# Altium schematic sheet DP.SchDoc
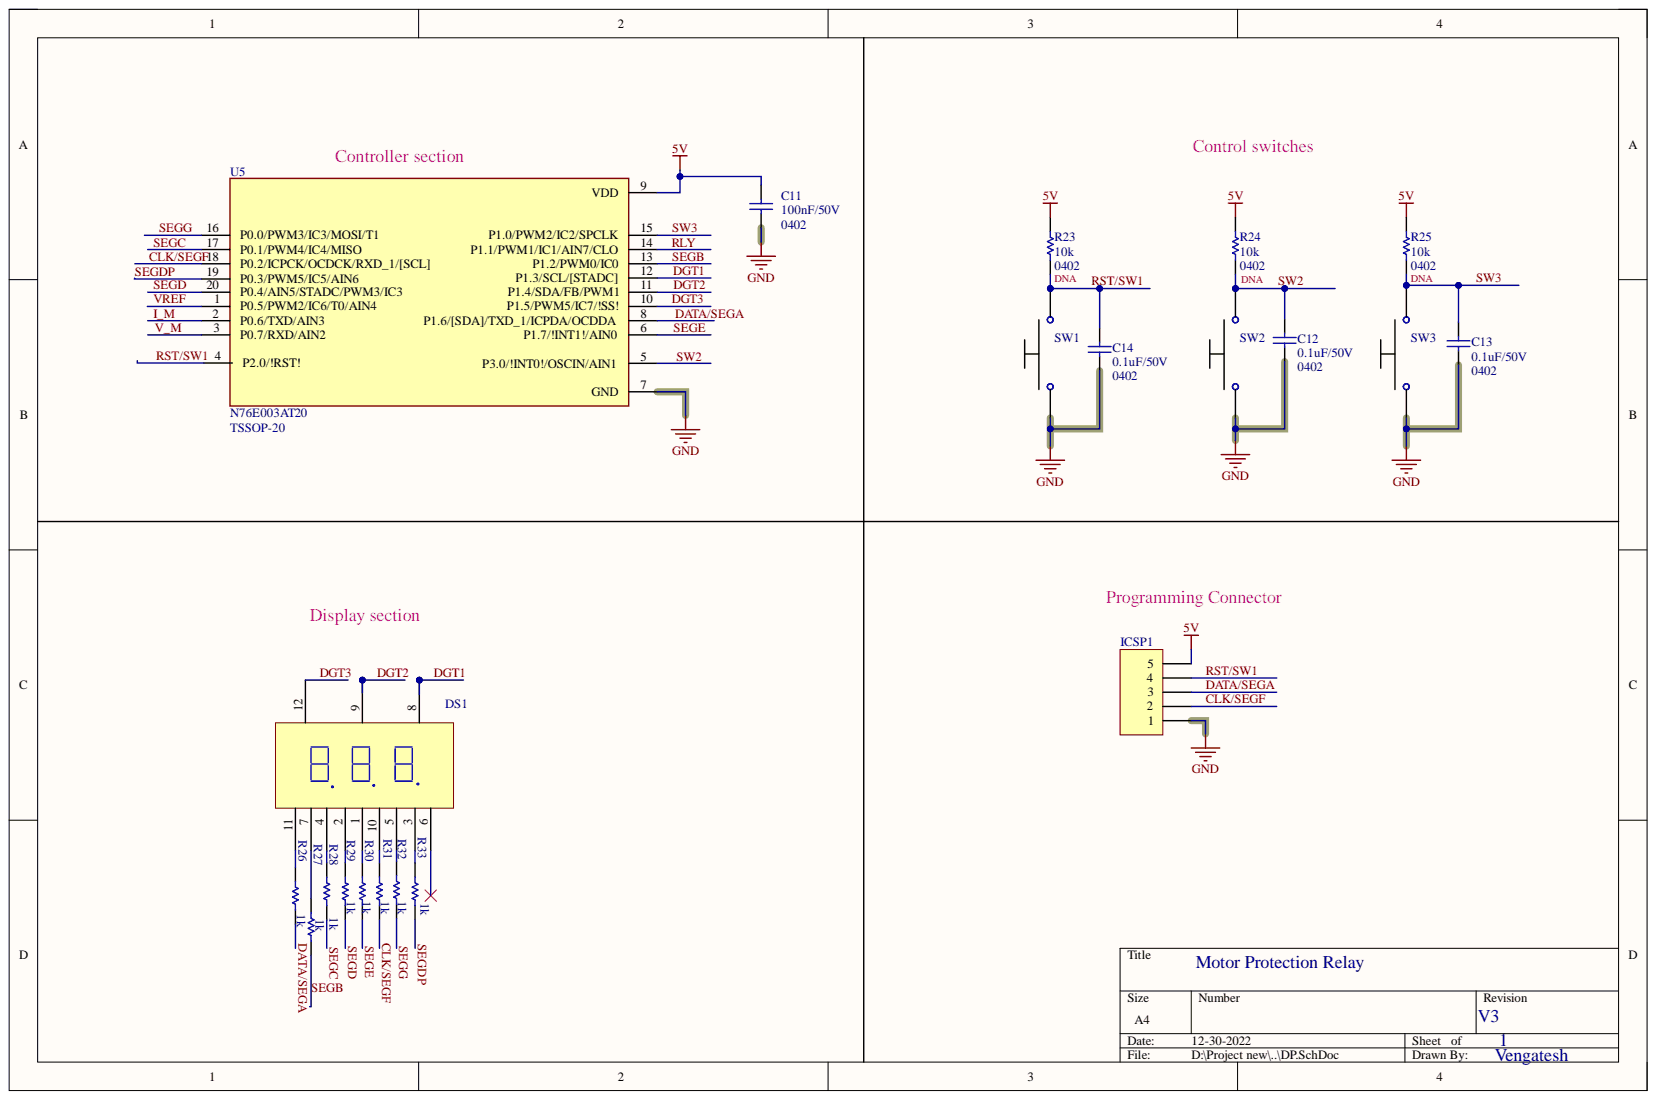
[diagram p0: DP.SchDoc]

## Parts
C11  value 100nF/50V  Package: 0402  [R_0402]  (hidden comment: Cap)
C12  value 0.1uF/50V  Package: 0402  [C_0402]  (hidden comment: Cap)
C13  value 0.1uF/50V  Package: 0402  [C_0402]  (hidden comment: Cap)
C14  value 0.1uF/50V  Package: 0402  [C_0402]  (hidden comment: Cap)
DS1  Display  [Seven Seg-3Digit]  (value not drawn)
ICSP1  Header 5  [HDR1X5]  (value not drawn)
R23  value 10k  Package: 0402  Populate: DNA  [R_0402]  (hidden comment: Res1)
R24  value 10k  Package: 0402  Populate: DNA  [R_0402]  (hidden comment: Res1)
R25  value 10k  Package: 0402  Populate: DNA  [R_0402]  (hidden comment: Res1)
R26  value 1k  [R_0402]  (hidden comment: Res1)
R27  value 1k  [R_0402]  (hidden comment: Res1)
R28  value 1k  [R_0402]  (hidden comment: Res1)
R29  value 1k  [R_0402]  (hidden comment: Res1)
R30  value 1k  [R_0402]  (hidden comment: Res1)
R31  value 1k  [R_0402]  (hidden comment: Res1)
R32  value 1k  [R_0402]  (hidden comment: Res1)
R33  value 1k  [R_0402]  (hidden comment: Res1)
SW1  Tact switch  [Tact Switch-S]  (value not drawn)
SW2  Tact switch  [Tact Switch-S]  (value not drawn)
SW3  Tact switch  [Tact Switch-S]  (value not drawn)
U5  N76E003AT20  Block: Controller section  Package: TSSOP-20  [TSSOP20]

## Nets
5V: C11.2 ICSP1.5 R23.2 R24.2 R25.2 U5.9(VDD)
GND: C11.1 C12.1 C13.1 C14.1 ICSP1.1 SW1.1 SW2.1 SW3.1 U5.7(GND)
CLK/SEGF: ICSP1.2 R31.2 U5.18(P0.2/ICPCK/OCDCK/RXD_1/[SCL])
DATA/SEGA: ICSP1.3 R26.2 U5.8(P1.6/[SDA]/TXD_1/ICPDA/OCDDA)
DGT1: DS1.8 U5.12(P1.3/SCL/[STADC])
DGT2: DS1.9 U5.11(P1.4/SDA/FB/PWM1)
DGT3: DS1.12 U5.10(P1.5/PWM5/IC7/!SS!)
I_M: U5.2(P0.6/TXD/AIN3)
RLY: U5.14(P1.1/PWM1/IC1/AIN7/CLO)
RST/SW1: C14.2 ICSP1.4 R23.1 SW1.2 U5.4(P2.0/!RST!)
SEGB: R27.1 U5.13(P1.2/PWM0/IC0)
SEGC: R28.2 U5.17(P0.1/PWM4/IC4/MISO)
SEGD: R29.2 U5.20(P0.4/AIN5/STADC/PWM3/IC3)
SEGDP: R33.2 U5.19(P0.3/PWM5/IC5/AIN6)
SEGE: R30.2 U5.6(P1.7/!INT1!/AIN0)
SEGG: R32.2 U5.16(P0.0/PWM3/IC3/MOSI/T1)
SW2: C12.2 R24.1 SW2.2 U5.5(P3.0/!INT0!/OSCIN/AIN1)
SW3: C13.2 R25.1 SW3.2 U5.15(P1.0/PWM2/IC2/SPCLK)
V_M: U5.3(P0.7/RXD/AIN2)
VREF: U5.1(P0.5/PWM2/IC6/T0/AIN4)
NetDS1_1: DS1.1 R30.1
NetDS1_2: DS1.2 R29.1
NetDS1_3: DS1.3 R33.1
NetDS1_4: DS1.4 R28.1
NetDS1_5: DS1.5 R32.1
NetDS1_7: DS1.7 R27.2
NetDS1_10: DS1.10 R31.1
NetDS1_11: DS1.11 R26.1

Not connected: DS1 6

## Library data of the parts
C11 = Cap: Capacitor, Ceramic, 100nF, 50V, 10% Tolerance, MLCC - SMD/SMT 0402 Package, PN: GRM155R71H104KE14D; Block: Controller section; Drawing no: 4922490001005000; Soldering points: 2; Component Kind: Standard; LatestRevisionDate: 17-Jul-2002; LatestRevisionNote: Re-released for DXP Platform.; Library Name: Miscellaneous Devices.IntLib; PackageDescription: Radial Cap, Thru-Hole; 2 Leads; 0.3 in Pin Spacing; PackageReference: 0402; Published: 8-Jun-2000; Signal Integrity: Cap; Simulation: CAP; Publisher: Altium Limited
C12-C14 = Cap: Capacitor, Ceramic, 100nF, 50V, 10% Tolerance, MLCC - SMD/SMT 0402 Package, PN: GRM155R71H104KE14D; Block: Control switch; Drawing no: 4922490001005000; Soldering points: 2; Component Kind: Standard; LatestRevisionDate: 17-Jul-2002; LatestRevisionNote: Re-released for DXP Platform.; Library Name: Miscellaneous Devices.IntLib; PackageDescription: Radial Cap, Thru-Hole; 2 Leads; 0.3 in Pin Spacing; Published: 8-Jun-2000; Signal Integrity: Cap; Simulation: CAP; Publisher: Altium Limited
DS1 = Display: LED DISPLAY, 7 SEGMENT, RED, 3 DIGIT, 0.56 INCH, THROUGH HOLE,RED COLOR,COMMON CATHODE,37.6X19X8mm; Block: Display section; Drawing no: 00101E917SLED358; Soldering points: 12; Component Kind: Standard; Package: Through hole; Library Name: Sakthi library.SchLib
ICSP1 = Header 5: Header, 5-Pin; Block: Programming connector; Soldering no: 5; Component Kind: Standard; LatestRevisionDate: 17-Jul-2002; LatestRevisionNote: Re-released for DXP Platform.; Library Name: Miscellaneous Connectors.IntLib; Signal Integrity: Connector; Publisher: Altium Limited
R23-R25 = Res1: RESISTOR, Chip Type, 10k, +/-1%, SMD, 0402 Package; Block: Control switch; Drawing No: 4218300003920000; MFG: Vishay/watts; Soldering points: 2; Component Kind: Standard; Signal Integrity: Res; Simulation: RESISTOR; Library Name: MPR.SchLib
R26-R33 = Res1: RESISTOR, Chip Type, 1k, +/-1%, SMD, 0402 Package; Block: Display selection; Drawing No: 4922511000000000; Soldering points: 2; Component Kind: Standard; Package: 0402; Signal Integrity: Res; Simulation: RESISTOR; Library Name: MPR.SchLib
SW1-SW3 = Tact switch: Block: Control switches; Soldering points: 4; Component Kind: Standard; Description: Tact switch; Package: Through hole; Library Name: MPR.SchLib
U5 = N76E003AT20: IC, uC, N76E003AT20-8051 Series, 16 MHz,20PIN, PACKAGE:TSSOP-20,PN:N76E003AT20; Drawing no: 00101E91UC76E003; MFG: Nuvoton Technology; Soldering points: 20; Availability: Unavailable; Component Kind: Standard; MFG no: N76E003AT20; SnapEDA_Link: https://www.snapeda.com/parts/N76E003AT20/Nuvoton%20Technology%20Corporation%20of%20America/view-part/652314/?ref=snap; Value: N76E003AT20; Library Name: VA Meter SCH Library.SCHLIB
